# Altium schematic sheet 2_MISC.SchDoc(2_MISC)
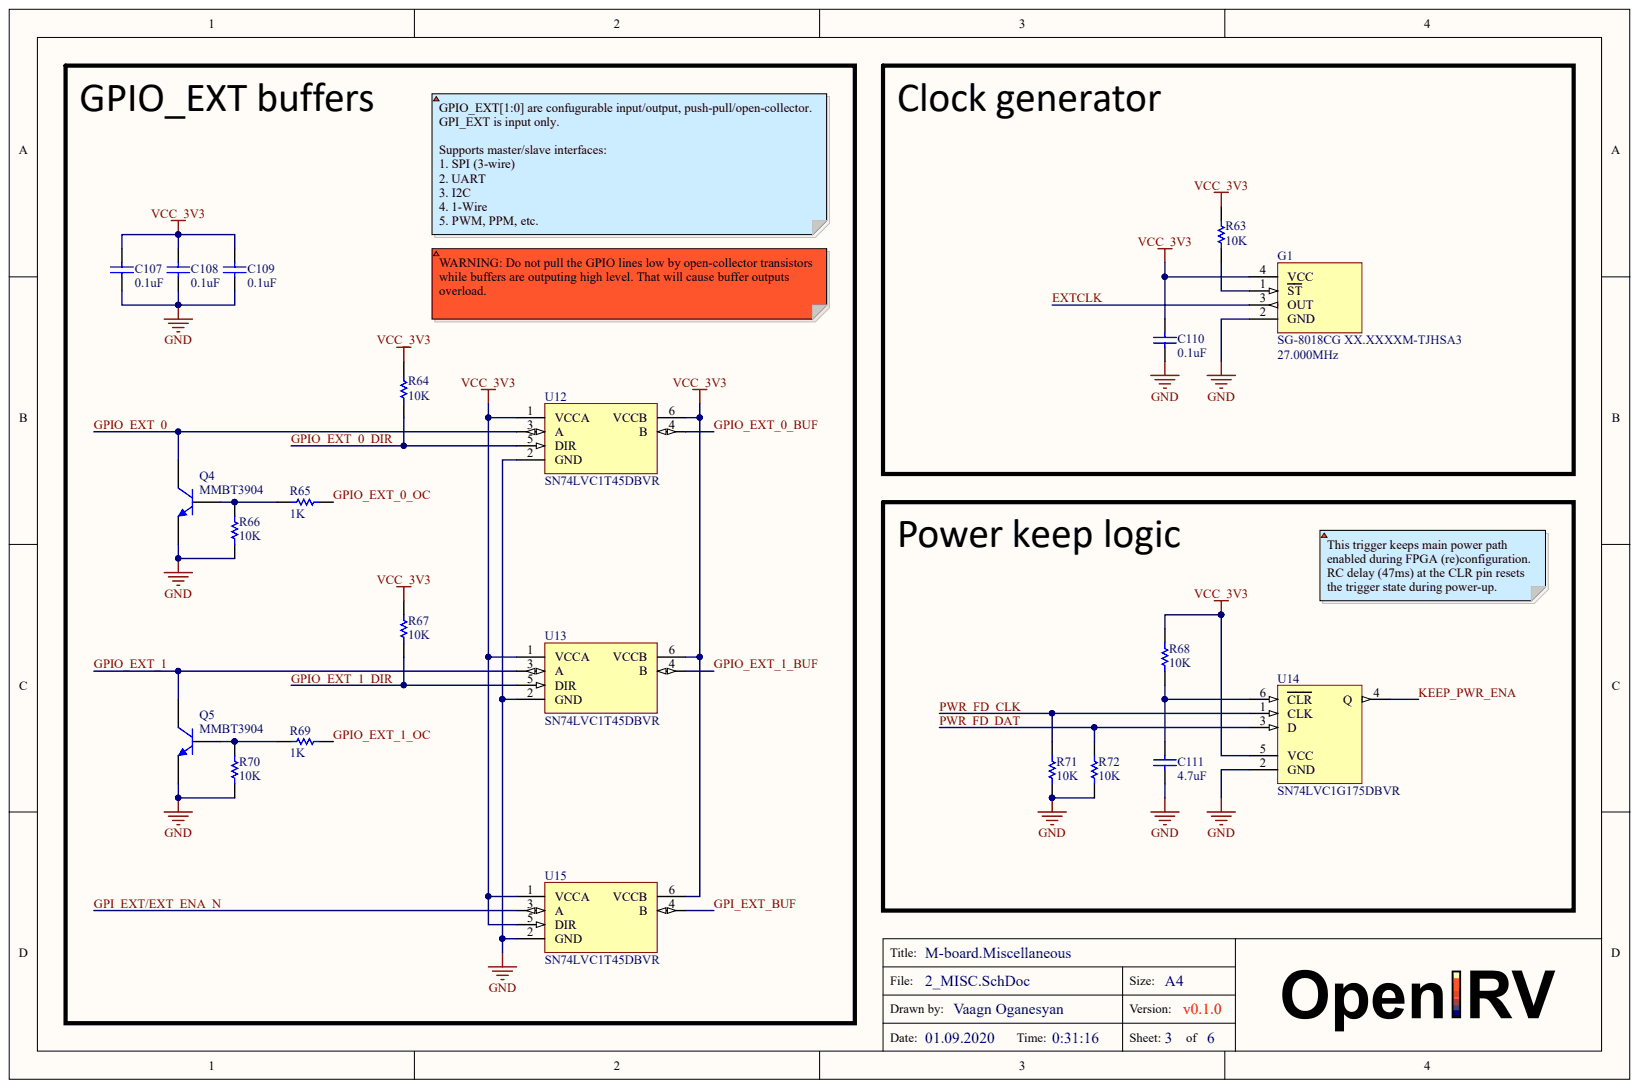
[diagram p0: 2_MISC.SchDoc]

## Parts
C107  0.1uF  [C0402[1005]]
C108  0.1uF  [C0402[1005]]
C109  0.1uF  [C0402[1005]]
C110  0.1uF  [C0402[1005]]
C111  4.7uF  [C0402[1005]]
G1  SG-8018CG XX.XXXXM-TJHSA3  Frequency: 27.000MHz  [SG-8018CG XX.XXXXM-TJHSA3]
Q4  MMBT3904  [MMBT3904]
Q5  MMBT3904  [MMBT3904]
R63  10K  [R0402[1005]]
R64  10K  [R0402[1005]]
R65  1K  [R0402[1005]]
R66  10K  [R0402[1005]]
R67  10K  [R0402[1005]]
R68  10K  [R0402[1005]]
R69  1K  [R0402[1005]]
R70  10K  [R0402[1005]]
R71  10K  [R0402[1005]]
R72  10K  [R0402[1005]]
U12  SN74LVC1T45DBVR  [SN74LVC1T45DBVR]
U13  SN74LVC1T45DBVR  [SN74LVC1T45DBVR]
U14  SN74LVC1G175DBVR  [SN74LVC1G175DBVR]
U15  SN74LVC1T45DBVR  [SN74LVC1T45DBVR]

## Nets
GND: C107.2 C108.2 C109.2 C110.2 C111.2 G1.2(GND) Q4.2 Q5.2 R66.1 R70.1 R71.1 R72.1 U12.2(GND) U13.2(GND) U14.2(GND) U15.2(GND)
VCC_3V3: C107.1 C108.1 C109.1 C110.1 G1.4(VCC) R63.2 R64.2 R67.2 R68.2 U12.1(VCCA) U12.6(VCCB) U13.1(VCCA) U13.6(VCCB) U14.5(VCC) U15.1(VCCA) U15.5(DIR) U15.6(VCCB)
EXTCLK: G1.3(OUT)
GPI_EXT/EXT_ENA_N: U15.3(A)
GPI_EXT_BUF: U15.4(B)
GPIO_EXT_0: Q4.3 U12.3(A)
GPIO_EXT_0_BUF: U12.4(B)
GPIO_EXT_0_DIR: R64.1 U12.5(DIR)
GPIO_EXT_0_OC: R65.1
GPIO_EXT_1: Q5.3 U13.3(A)
GPIO_EXT_1_BUF: U13.4(B)
GPIO_EXT_1_DIR: R67.1 U13.5(DIR)
GPIO_EXT_1_OC: R69.1
KEEP_PWR_ENA: U14.4(Q)
PWR_FD_CLK: R71.2 U14.1(CLK)
PWR_FD_DAT: R72.2 U14.3(D)
NetC111_1: C111.1 R68.1 U14.6(CLR)
NetG1_1: G1.1(ST) R63.1
NetQ4_1: Q4.1 R65.2 R66.2
NetQ5_1: Q5.1 R69.2 R70.2

## Library data of the parts
C107-C110 = CAP: Dielectric: X7R; Tolerance: 10%; Voltage: 50V; Component Kind: Standard; Library Name: general.IntLib; Mounting: SMD; Value: 0.1uF
C111 = CAP: Dielectric: X5R; Tolerance: 10%; Voltage: 6.3V; Component Kind: Standard; Library Name: general.IntLib; Mounting: SMD; Value: 4.7uF
G1 = SG-8018CG XX.XXXXM-TJHSA3: Oscillator; Component Kind: Standard; Library Name: general.IntLib; ManufacturerPartNumber: SG-8018CG XX.XXXXM-TJHSA3
R63-R72 = RES: Power: 0.06W; Tolerance: 5%; Component Kind: Standard; Library Name: general.IntLib; Mounting: SMD
  R63 R64 R66-R68 R70-R72: Value: 10K
  R65 R69: Value: 1K
U12 U13 U15 = SN74LVC1T45DBVR: Single-Bit Dual-Supply Bus Transceiver; Component Kind: Standard; Library Name: general.IntLib
Q4 Q5 = MMBT3904: Component Kind: Standard; Library Name: general.IntLib
U14 = SN74LVC1G175DBVR: Component Kind: Standard; Library Name: general.IntLib
